# Altium schematic sheet Controller.SchDoc
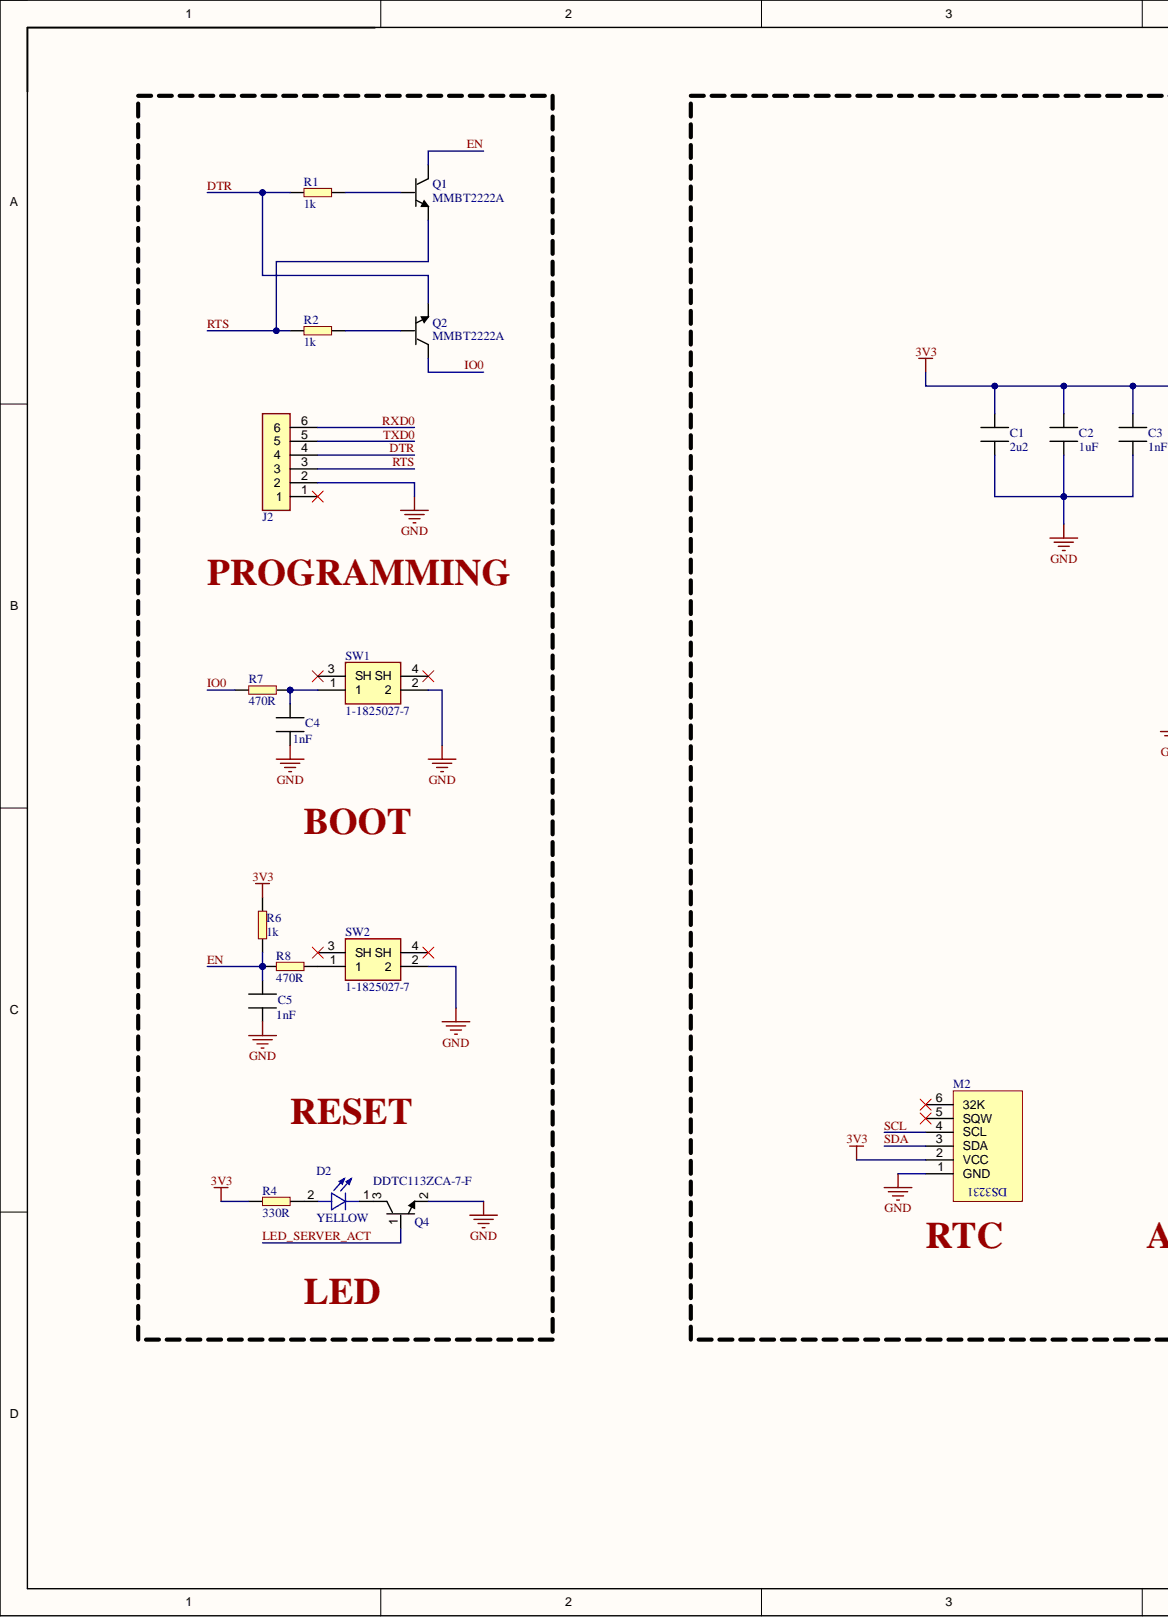
[diagram p0: Controller.SchDoc, left]
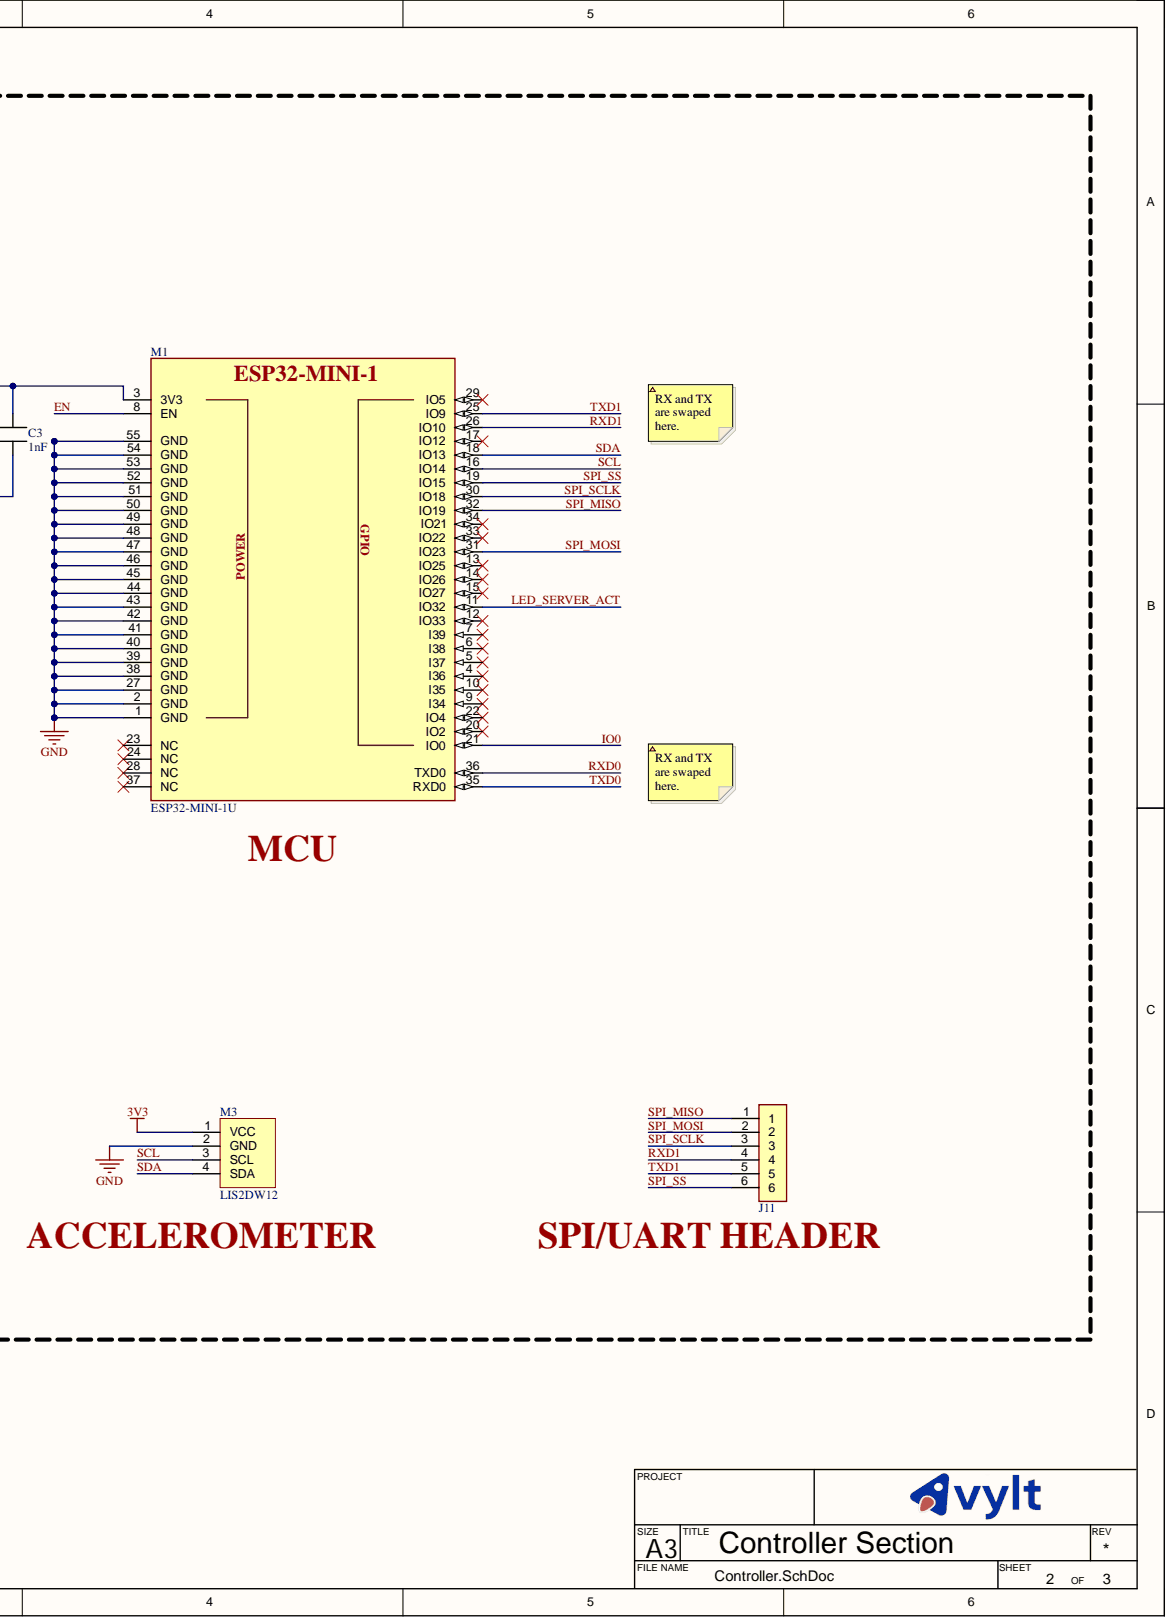
[diagram p1: Controller.SchDoc, right]

## Parts
C1  value 2u2  [CAPC1608X10N]  (hidden comment: ERP_102-1)
C2  value 1uF  [CAPC1608X10N]  (hidden comment: ERP_102-1)
C3  value 1nF  [CAPC1608X10N]  (hidden comment: ERP_102-1)
C4  value 1nF  [CAPC1608X10N]  (hidden comment: ERP_102-1)
C5  value 1nF  [CAPC1608X10N]  (hidden comment: ERP_102-1)
D2  value YELLOW  [LED_2SMD]  (hidden comment: LED_2SMD)
J2  ERP_148-2  [6PX1R]  (value not drawn)  (no BOM)
J11  ERP_148-2  [6PX1R]  (value not drawn)
M1  value ESP32-MINI-1U  [ESP32_MINI_1]  (hidden comment: ERP_140-3)
M2  DS3231_HW-084  [DS3231_HW-084]  (value not drawn)
M3  value LIS2DW12  [SEN0409]  (hidden comment: SEN0409)
Q1  value MMBT2222A  [MMBT2222]  (hidden comment: ERP_105-1)
Q2  value MMBT2222A  [MMBT2222]  (hidden comment: ERP_105-1)
Q4  value DDTC113ZCA-7-F  [DDTCXXXXCA]  (hidden comment: ERP_105-1)
R1  value 1k  [RES_0603]  (hidden comment: ERP_101-1)
R2  value 1k  [RES_0603]  (hidden comment: ERP_101-1)
R4  value 330R  [RES_0603]  (hidden comment: ERP_101-1)
R6  value 1k  [RES_0603]  (hidden comment: ERP_101-1)
R7  value 470R  [RES_0603]  (hidden comment: ERP_101-1)
R8  value 470R  [RES_0603]  (hidden comment: ERP_101-1)
SW1  value 1-1825027-7  Manufacturer Part Number 1: 1-1825027-7  [FSM_6X6]  (hidden comment: ERP_110-4)
SW2  value 1-1825027-7  Manufacturer Part Number 1: 1-1825027-7  [FSM_6X6]  (hidden comment: ERP_110-4)

## Nets
3V3: C1.2 C2.2 C3.2 M1.3(3V3) M2.2(VCC) M3.1(VCC) R4.2 R6.2
GND: C1.1 C2.1 C3.1 C4.2 C5.2 J2.2 M1.1(GND) M1.2(GND) M1.27(GND) M1.38(GND) M1.39(GND) M1.40(GND) M1.41(GND) M1.42(GND) M1.43(GND) M1.44(GND) M1.45(GND) M1.46(GND) M1.47(GND) M1.48(GND) M1.49(GND) M1.50(GND) M1.51(GND) M1.52(GND) M1.53(GND) M1.54(GND) M1.55(GND) M2.1(GND) M3.2(GND) Q4.2 SW1.2 SW2.2
DTR: J2.4 Q2.2 R1.2
EN: C5.1 M1.8(EN) Q1.3 R6.1 R8.2
IO0: M1.21(IO0) Q2.3 R7.2
LED_SERVER_ACT: M1.11(IO32) Q4.1
RTS: J2.3 Q1.2 R2.2
RXD0: J2.6 M1.36(TXD0)
RXD1: J11.4 M1.26(IO10)
SCL: M1.16(IO14) M2.4(SCL) M3.3(SCL)
SDA: M1.18(IO13) M2.3(SDA) M3.4(SDA)
SPI_MISO: J11.1 M1.32(IO19)
SPI_MOSI: J11.2 M1.31(IO23)
SPI_SCLK: J11.3 M1.30(IO18)
SPI_SS: J11.6 M1.19(IO15)
TXD0: J2.5 M1.35(RXD0)
TXD1: J11.5 M1.25(IO9)
NetC4_1: C4.1 R7.1 SW1.1
NetD2_1: D2.1 Q4.3
NetD2_2: D2.2 R4.1
NetQ1_1: Q1.1 R1.1
NetQ2_1: Q2.1 R2.1
NetR8_1: R8.1 SW2.1

Not connected: J2 1; M1 4(I36) 5(I37) 6(I38) 7(I39) 9(I34) 10(I35) 12(IO33) 13(IO25) 14(IO26) 15(IO27) 17(IO12) 20(IO2) 22(IO4) 23(NC) 24(NC) 28(NC) 29(IO5) 33(IO22) 34(IO21) 37(NC); M2 5(SQW) 6(32K); SW1 3(SH) 4(SH); SW2 3(SH) 4(SH)

## Library data of the parts
C1 = MLCC_0603: MLCC 0603; Manufacturer 1: Murata Electronics; Manufacturer Part Number 1: GRT188R61H225KE13D; Component Kind: Standard; Library Name: Capacitor.SchLib; Package: 0603; Supplier 1: Digikey; Supplier Part Number 1: 490-12332-1-ND
C2 = MLCC_0603: MLCC 0603; Manufacturer 1: Samsung Electro-Mechanics; Manufacturer Part Number 1: CL10A105KB8NNNC; Component Kind: Standard; Library Name: Capacitor.SchLib; Package: 0603
C3-C5 = MLCC_0603: MLCC 0603; Manufacturer 1: Samsung Electro-Mechanics; Manufacturer Part Number 1: CL10C102JB8NNNC; Component Kind: Standard; Library Name: Capacitor.SchLib; Package: 0603; Supplier 1: Digikey; Supplier Part Number 1: 1276-1091-1-ND
D2 = LED_2SMD: LED YELLOW CLEAR 1204 SMD R/A; Manufacturer 1: Würth Elektronik; Manufacturer Part Number 1: 155124YS73200; Component Kind: Standard; Library Name: LED.SchLib; Package: 1204; Supplier 1: Digikey
J2 J11 = 6PX1R: Description: 6PX1R; Library Name: Male_Header_P2.54.SchLib
  J11: Component Kind: Standard
M1 = ESP32_MINI_1: ESP32_C3_MINI_1 Module; Manufacturer 1: Espressif Systems; Manufacturer Part Number 1: ESP32-MINI-1U-N4; Component Kind: Standard; Library Name: ESP.SchLib; Package: 56 PIN SMD; Supplier 1: Digikey; Supplier Part Number 1: 1965-ESP32-MINI-1U-N4CT-ND
M2 = DS3231_HW-084: DS3231 Time Module , HW-084; Manufacturer 1: Generic; Manufacturer Part Number 1: HW-084(DS3231); Component Kind: Standard; Library Name: Time.SchLib; Package: 6 Pin TH; Value: HW-084
M3 = SEN0409: GRAVITY LIS2DW12 ACCELEROMETER; Manufacturer 1: DFRobot; Component Kind: Standard; Manufacturer Part Number 1: SEN0409; Library Name: WiredModule.SchLib
Q1 Q2 = MMBT2222: MMBT2222A; Manufacturer 1: Diotec Semiconductor; Manufacturer Part Number 1: MMBT2222A-7-F; Component Kind: Standard; Library Name: BJT.SchLib; Package: SOT23
Q4 = DDTCXXXXCA: TRANS PREBIAS NPN 50V SOT23-3; Manufacturer 1: Diodes Incorporated; Manufacturer Part Number 1: DDTC113ZCA-7-F; Value: DDTC113ZCA-7-F; Component Kind: Standard; Library Name: BJT.SchLib; Package: SOT23; Supplier 1: Digikey
R1 R2 R6 = RES_0603: Manufacturer 1: YAGEO; Manufacturer Part Number 1: RC0603FR-071KL; Component Kind: Standard; Description: RES_0603; Library Name: Resistor.SchLib; Package: 0603; Supplier 1: Digikey; Supplier Part Number 1: 311-1.00KHRCT-ND
R4 = RES_0603: Manufacturer 1: YAGEO; Manufacturer Part Number 1: RC0603JR-07330RL; Component Kind: Standard; Description: RES_0603; Library Name: Resistor.SchLib; Package: 0603
R7 R8 = RES_0603: Manufacturer 1: YAGEO; Manufacturer Part Number 1: RC0603JR-07470RL; Component Kind: Standard; Description: RES_0603; Library Name: Resistor.SchLib; Package: 0603; Supplier 2: Digikey; Supplier Part Number 2: 311-470GRCT-ND
SW1 SW2 = FSM_6X6: Manufacturer 1: TE; Component Kind: Standard; Library Name: Switch.SchLib; Package: 6X6; Supplier 1: Digikey; Supplier Part Number 1: 450-1660-ND
